# Altium schematic sheet Detection_Circuit_Sheet_01.SchDoc
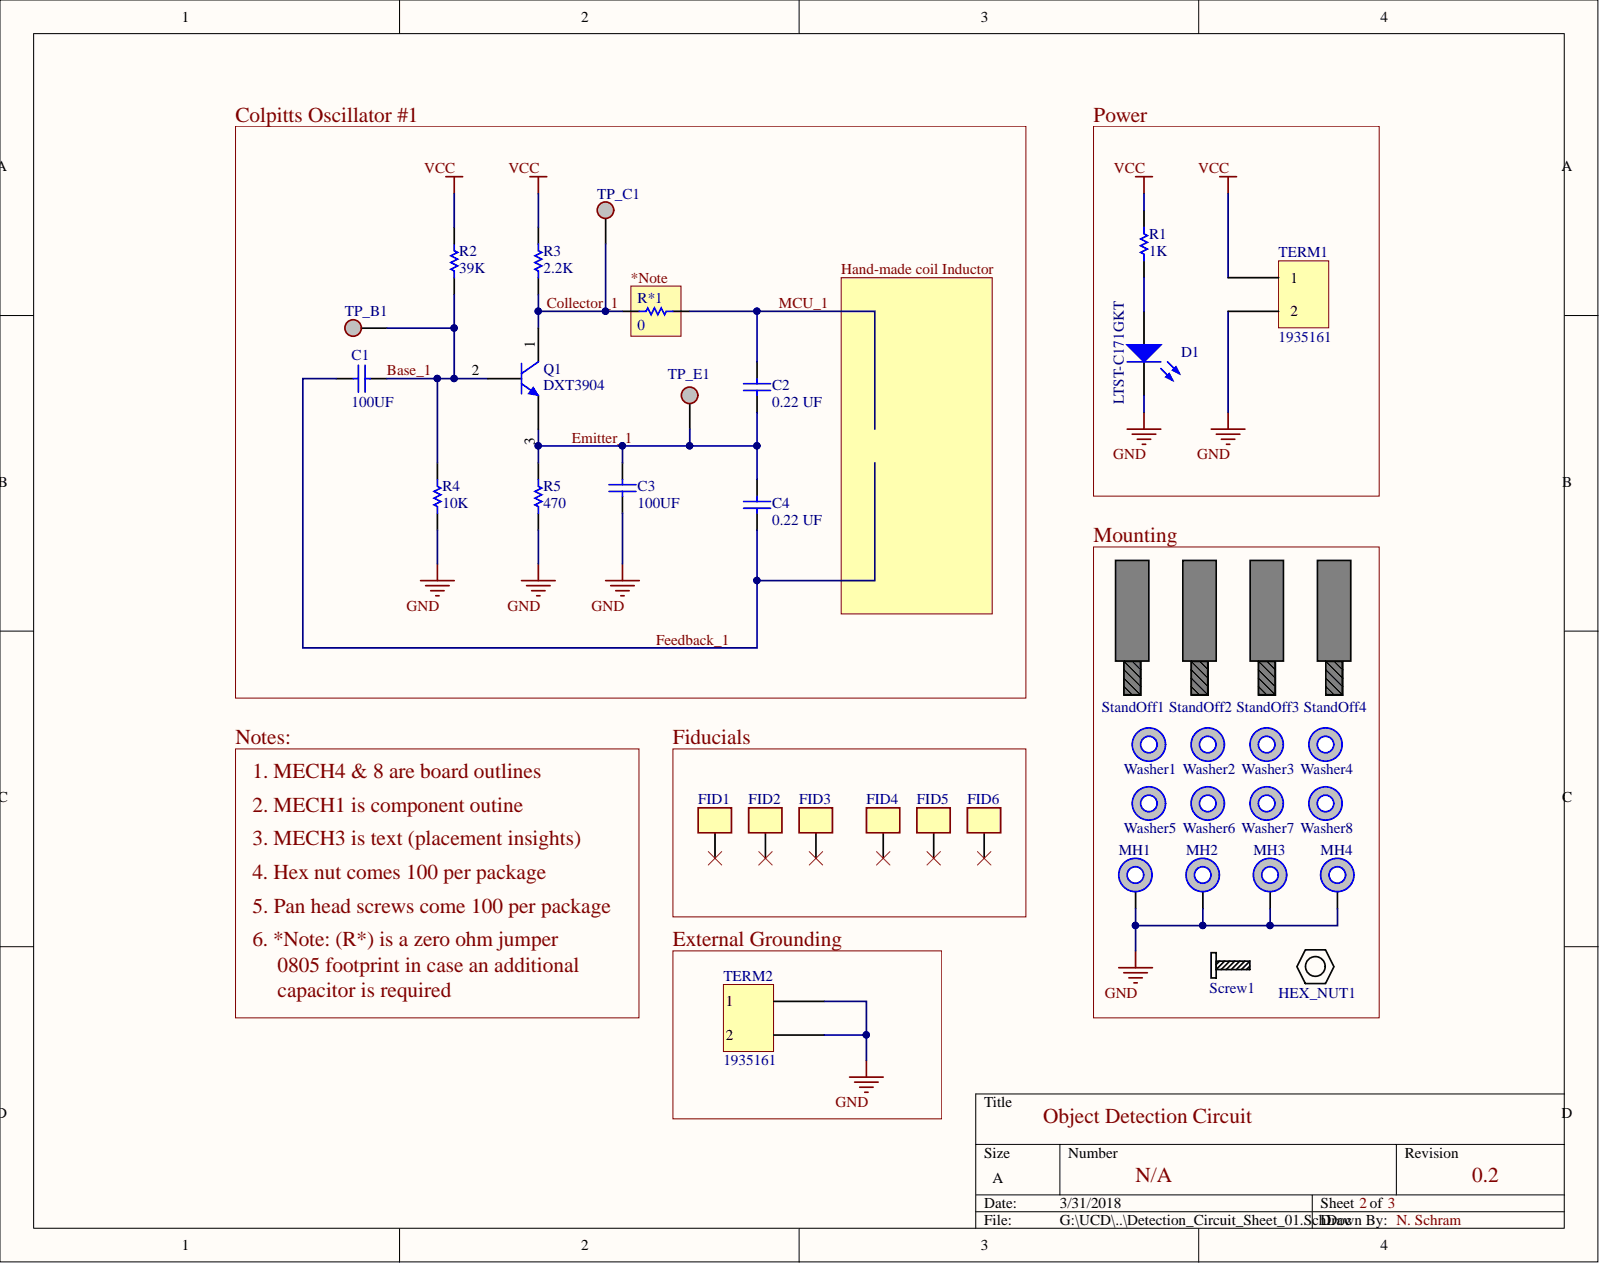
[diagram p0: Detection_Circuit_Sheet_01.SchDoc]

## Parts
C1  100UF  [C1210_M]
C2  08055C224JAT2A  [6-0805_N]  (value not drawn)
C3  100UF  [C1210_M]
C4  08055C224JAT2A  [6-0805_N]  (value not drawn)
D1  LTST-C171GKT  [6-0805_M]
FID1  Fiducial  [Fiducial]
FID2  Fiducial  [Fiducial]
FID3  Fiducial  [Fiducial]
FID4  Fiducial  [Fiducial]
FID5  Fiducial  [Fiducial]
FID6  Fiducial  [Fiducial]
HEX_NUT1  6-32 Hex Nut  (value not drawn)
MH1  #6 MH  [#6_MH]  (value not drawn)
MH2  #6 MH  [#6_MH]  (value not drawn)
MH3  #6 MH  [#6_MH]  (value not drawn)
MH4  #6 MH  [#6_MH]  (value not drawn)
Q1  DXT3904  [DXT3904-13]
R1  1K  [6-0805_M]
R2  39K  [6-0805_M]
R3  2.2K  [6-0805_M]
R4  10K  [6-0805_M]
R5  470  [6-0805_M]
R*1  0  [6-0805_M]
Screw1  6-32 Pan Head Screw  (value not drawn)
StandOff1  6-32 Stand Off  (value not drawn)
StandOff2  6-32 Stand Off  (value not drawn)
StandOff3  6-32 Stand Off  (value not drawn)
StandOff4  6-32 Stand Off  (value not drawn)
TERM1  1935161  [1935161]
TERM2  1935161  [1935161]
TP_B1  TestPoint  [TestPoint]  (value not drawn)
TP_C1  TestPoint  [TestPoint]  (value not drawn)
TP_E1  TestPoint  [TestPoint]  (value not drawn)
Washer1  Nylon Washer  (value not drawn)
Washer2  Nylon Washer  (value not drawn)
Washer3  Nylon Washer  (value not drawn)
Washer4  Nylon Washer  (value not drawn)
Washer5  Nylon Washer  (value not drawn)
Washer6  Nylon Washer  (value not drawn)
Washer7  Nylon Washer  (value not drawn)
Washer8  Nylon Washer  (value not drawn)

## Nets
GND: C3.1 D1.2 MH1.MH MH2.MH MH3.MH MH4.MH R4.1 R5.1 TERM1.2 TERM2.1 TERM2.2
VCC: R1.2 R2.2 R3.2 TERM1.1
BASE_1: C1.2 Q1.2 R2.1 R4.2 TP_B1.1
COLLECTOR_1: Q1.1 R3.1 R*1.1 TP_C1.1
EMITTER_1: C2.1 C3.2 C4.2 Q1.3 R5.2 TP_E1.1
FEEDBACK_1: C1.1 C4.1
MCU_1: C2.2 R*1.2
NetD1_1: D1.1 R1.1

Not connected: FID1 FID; FID2 FID; FID3 FID; FID4 FID; FID5 FID; FID6 FID

## Library data of the parts
C1 C3 = CC1210MKX5R7BB107: CAP CER 100UF 16V X5R 1210; Manufacturer: Yageo; Capacitance: 100µF; Tolerance: ±20%; Applications: General Purpose; Category: Capacitors; Component Kind: Standard; ComponentLink1Description: http://www.mouser.com/ds/2/447/Yageo_UPY-GP_NP0_16V-to-50V_9-358835.pdf; ComponentLink1URL: http://www.mouser.com/ds/2/447/Yageo_UPY-GP_NP0_16V-to-50V_9-358835.pdf; ComponentLink2Description: http://www.yageo.com/documents/recent/UPY-GPHC_X5R_4V-to-50V_25.pdf; ComponentLink2URL: http://www.yageo.com/documents/recent/UPY-GPHC_X5R_4V-to-50V_25.pdf; Family: Ceramic Capacitors; Features: -; Height - Seated (Max): -; Lead Spacing: -; Lead Style: -; Library Name: CC1210MKX5R7BB107.SchLib; Manufacturer Part Number: CC1210MKX5R7BB107; Mounting Type: Surface Mount, MLCC; Operating Temperature: -55°C ~ 85°C; Package / Case: 1210 (3225 Metric); Packaging: Tape & Reel (TR); Pricing 1: 1=1.82, 10=1.437, 100=1.0778 (USD); Ratings: -; Series: CC; Size / Dimension: 0.126" L x 0.098" W (3.20mm x 2.50mm); Stock 1: 19901; Supplier 1: Digi-Key; Supplier Part Number 1: 311-2052-1-ND; Temperature Coefficient: X5R; Thickness (Max): 0.110" (2.80mm); Voltage - Rated: 16V
C2 C4 = 08055C224JAT2A: CAP CER 0.22UF 50V X7R 0805; Manufacturer: AVX Corporation; Notification: Current market demand for these product types have resulted in fluctuating and extending lead times. Lead times may differ.; Tolerance: ±5%; Applications: General Purpose; Capacitance: 0.22 UF; Category: Capacitors; Component Kind: Standard; ComponentLink1Description: http://datasheets.avx.com/X7RDielectric.pdf; ComponentLink1URL: http://datasheets.avx.com/X7RDielectric.pdf; Features: -; Height - Seated (Max): -; Lead Spacing: -; Lead Style: -; Library Name: 08055C224JAT2A.SchLib; Manufacturer Part Number: 08055C224JAT2A; Mounting Type: Surface Mount, MLCC; Operating Temperature: -55°C ~ 125°C; Package / Case: 0805 (2012 Metric); Packaging: Cut Tape (CT); Part Status: Active; Pricing 1: 1=0.55, 10=0.387, 100=0.255, 500=0.18886, 1000=0.16053 (USD); Ratings: -; RoHS: RoHS Compliant; Size / Dimension: 0.079" L x 0.049" W (2.00mm x 1.25mm); Stock 1: 25172; Supplier 1: Digi-Key; Supplier Part Number 1: 478-7437-1-ND; Temperature Coefficient: X7R; Thickness (Max): 0.037" (0.94mm); Voltage - Rated: 50V
D1 = LED3: LED GREEN CLEAR 0805 SMD; Manufacturer: Vishay Lite-On; Chip Material: GaP; DELETED: Compliant; Dominant Wave Length: 569 nm; Emitting Color: Yellow Green; Forward Current: 30 mA; Forward Voltage: 2.6 V; High Brightness: No; Luminous Intensity: 12mcd; Main Category: Chip LED; Number of  Elements: 1; Peak Wavelength: 565 nm; Power Dissipation: 100 W; Product Depth (mm): 1.25 mm; Product Height (mm): 0.8 mm; Product Length (mm): 2 mm; Reverse Voltage: 5 V; Shape Type: Rectangular; Test Current (It): 10 mA; Type: Uni-Color; Viewing Angle: 130 deg; Component Kind: Standard; ComponentLink1Description: https://datasheet.ciiva.com/2110/ltst-c171gkt-2110000.pdf; ComponentLink1URL: https://datasheet.ciiva.com/2110/ltst-c171gkt-2110000.pdf; ComponentLink2Description: https://datasheet.ciiva.com/2110/ltst-c171gkt-2110001.pdf; ComponentLink2URL: https://datasheet.ciiva.com/2110/ltst-c171gkt-2110001.pdf; ComponentLink3Description: https://datasheet.ciiva.com/2110/ltst-c171gkt-2110002.pdf; ComponentLink3URL: https://datasheet.ciiva.com/2110/ltst-c171gkt-2110002.pdf; ComponentLink4Description: https://datasheet.ciiva.com/16396/ltst-c171gkt-16396549.pdf; ComponentLink4URL: https://datasheet.ciiva.com/16396/ltst-c171gkt-16396549.pdf; ComponentLink5Description: https://datasheet.ciiva.com/11773/0900766b80dc0296-11773991.pdf; ComponentLink5URL: https://datasheet.ciiva.com/11773/0900766b80dc0296-11773991.pdf; ComponentLink6Description: https://datasheet.ciiva.com/12400/lite-on-ltst-c171gkt-190133-12400647.pdf; ComponentLink6URL: https://datasheet.ciiva.com/12400/lite-on-ltst-c171gkt-190133-12400647.pdf; Lens Dimensions: 1.25X1.4X0.5 mm; Library Name: Miscellaneous Devices.IntLib; Lum Intensity: 12mcd; Manufacturer Part Number: LTST-C171GKT; Mounting: Surface Mount; Operating Temperature Classification: Industrial; Operating Temp Range: -55C to 85C; Package Type: Chip Led; Packaging: Tape and Reel; Pin Count: 2; Pricing 1: 1=0.3, 10=0.238, 100=0.1296, 250=0.0864, 500=0.0734, 1000=0.0562 (USD); Rad Hardened: No; Stock 1: 158224; Supplier 1: Digi-Key; Supplier Part Number 1: 160-1423-1-ND
HEX_NUT1 = HEX_NUT: HEX NUT 0.245" STEEL 6-32; Manufacturer Part Number: 4701; Brand: Keystone Electronics; Gender: Female; Plating: Zinc; Component Kind: Standard; ComponentLink1Description: https://datasheet.ciiva.com/41799/4701-41799174.pdf; ComponentLink1URL: https://datasheet.ciiva.com/41799/4701-41799174.pdf; ComponentLink2Description: https://datasheet.ciiva.com/18416/70181805-18416126.pdf; ComponentLink2URL: https://datasheet.ciiva.com/18416/70181805-18416126.pdf; Factory Pack Quantity: 100; Features: Universal Mounting Kits; Length: 6.22 mm; Library Name: 6-32_Hex_Nut.SchLib; Manufacturer: Keystone Electronics; Material: Steel; Pricing 1: 1=0.1, 10=0.049, 50=0.0342, 100=0.0293, 250=0.0283, 500=0.0244, 1000=0.0219, 2500=0.0195, 5000=0.0185 (USD); Product Category: Screws & Fasteners; RoHS: Details; Stock 1: 51671; Supplier 1: Digi-Key; Supplier Part Number 1: 36-4701-ND; Thickness: 2.41 mm; Thread Size: 6-32; Type: Nuts; Width: 6.22 mm
MH1-MH4 = MH: #6 Mounting Hole; Component Kind: Standard; Library Name: #6_MH.SchLib
Q1 = DXT3904-13: TRANS NPN 40V 0.2A SOT89-3; Manufacturer: Diodes; Brand: Diodes Incorporated; Collector- Base Voltage VCBO: 60 V; Collector-Emitter Saturation Voltage: 300 mV; Configuration: Single; DC Collector/Base Gain hfe Min: 30 at 100 mA, 1 V; Emitter- Base Voltage VEBO: 6 V; Gain Bandwidth Product fT: 300 MHz; Maximum DC Collector Current: 200 mA; Pd - Power Dissipation: 1000 mW; Unit Weight: 0.001834 oz; Collector- Emitter Voltage VCEO Max: 40 V; Component Kind: Standard; ComponentLink1Description: https://datasheet.ciiva.com/979/ds31141-979988.pdf; ComponentLink1URL: https://datasheet.ciiva.com/979/ds31141-979988.pdf; ComponentLink2Description: https://datasheet.ciiva.com/4724/ds31141-4724919.pdf; ComponentLink2URL: https://datasheet.ciiva.com/4724/ds31141-4724919.pdf; ComponentLink3Description: https://datasheet.ciiva.com/17303/ds31141-17303788.pdf; ComponentLink3URL: https://datasheet.ciiva.com/17303/ds31141-17303788.pdf; ComponentLink4Description: https://datasheet.ciiva.com/11206/ds31141-11206239.pdf; ComponentLink4URL: https://datasheet.ciiva.com/11206/ds31141-11206239.pdf; Factory Pack Quantity: 2500; Height: 1.5 mm; Length: 4.5 mm; Library Name: DXT3904-13.SchLib; Manufacturer Part Number: DXT3904-13; Maximum Operating Temperature: + 150 C; Minimum Operating Temperature: - 55 C; Mounting Style: SMD/SMT; Package / Case: SOT-89; Packaging: Reel; Pricing 1: 1=0.53, 10=0.426, 100=0.29, 500=0.2175, 1000=0.1632 (USD); Product Category: Bipolar Transistors - BJT; RoHS: True; Series: DXT3904; Stock 1: 10942; Supplier 1: Digi-Key; Supplier Part Number 1: DXT3904-13DICT-ND; Transistor Polarity: NPN; Width: 2.48 mm
R1 = RNCP0805FTD1K00: RES SMD 1K OHM 1% 1/4W 0805; Manufacturer: Stackpole Electronics; Power (Watts): 0.25W, 1/4W; Temperature Coefficient: ±100ppm/°C; Category: Resistors; Component Kind: Standard; ComponentLink1Description: http://www.seielect.com/Catalog/SEI-rncp.pdf; ComponentLink1URL: http://www.seielect.com/Catalog/SEI-rncp.pdf; Composition: Thin Film; Family: Chip Resistor - Surface Mount; Features: Anti-Sulfur, Moisture Resistant; Height: 0.024" (0.60mm); Library Name: RNCP0805FTD1K00.SchLib; Manufacturer Part Number: RNCP0805FTD1K00; Number of Terminations: 2; Operating Temperature: -55°C ~ 155°C; Package / Case: 0805 (2012 Metric); Packaging: Tape & Reel (TR); Pricing 1: 1=0.1, 10=0.037, 100=0.015, 1000=0.0067, 2500=0.0058 (USD); Resistance (Ohms): 1k; Series: RNCP; Size / Dimension: 0.079" L x 0.049" W (2.00mm x 1.25mm); Stock 1: 107354; Supplier 1: Digi-Key; Supplier Device Package: 0805; Supplier Part Number 1: RNCP0805FTD1K00CT-ND; Tolerance: ±1%
R2 = ERJ-PB6D3902V: RES SMD 39K OHM 0.5% 1/4W 0805; Manufacturer: Panasonic; Power (Watts): 0.25W, 1/4W; Temperature Coefficient: ±50ppm/°C; Category: Resistors; Component Kind: Standard; ComponentLink1Description: https://industrial.panasonic.com/sa/system/files/data/download/catalog/id_fixed-resistors_e_2.pdf; ComponentLink1URL: https://industrial.panasonic.com/sa/system/files/data/download/catalog/id_fixed-resistors_e_2.pdf; Composition: Thick Film; Failure Rate: -; Family: Chip Resistor - Surface Mount; Features: Automotive AEC-Q200, Pulse Withstanding; Height - Seated (Max): 0.028" (0.70mm); Library Name: ERJ-PB6D3902V.SchLib; Manufacturer Part Number: ERJ-PB6D3902V; Number of Terminations: 2; Operating Temperature: -55°C ~ 155°C; Package / Case: 0805 (2012 Metric); Packaging: Tape & Reel (TR); Part Status: Active; Pricing 1: 1=0.24, 10=0.204, 25=0.1488, 50=0.1078, 100=0.0799, 250=0.0614, 500=0.0483, 1000=0.0335 (USD); Resistance (Ohms): 39k; Series: ERJ; Size / Dimension: 0.079" L x 0.049" W (2.00mm x 1.25mm); Stock 1: 2236; Supplier 1: Digi-Key; Supplier Device Package: 0805; Supplier Part Number 1: P21199CT-ND; Tolerance: ±0.5%
R3 = ERJ-PB6D2201V: RES SMD 2.2K OHM 0.5% 1/4W 0805; Manufacturer: Panasonic; Power (Watts): 0.25W, 1/4W; Temperature Coefficient: ±50ppm/°C; Category: Resistors; Component Kind: Standard; ComponentLink1Description: https://industrial.panasonic.com/sa/system/files/data/download/catalog/id_fixed-resistors_e_2.pdf; ComponentLink1URL: https://industrial.panasonic.com/sa/system/files/data/download/catalog/id_fixed-resistors_e_2.pdf; Composition: Thick Film; Failure Rate: -; Family: Chip Resistor - Surface Mount; Features: Automotive AEC-Q200, Pulse Withstanding; Height - Seated (Max): 0.028" (0.70mm); Library Name: ERJ-PB6D2201V.SchLib; Manufacturer Part Number: ERJ-PB6D2201V; Number of Terminations: 2; Operating Temperature: -55°C ~ 155°C; Package / Case: 0805 (2012 Metric); Packaging: Tape & Reel (TR); Part Status: Active; Pricing 1: 1=0.24, 10=0.204, 25=0.1488, 50=0.1078, 100=0.0799, 250=0.0614, 500=0.0483, 1000=0.0335 (USD); Resistance (Ohms): 2.2k; Series: ERJ; Size / Dimension: 0.079" L x 0.049" W (2.00mm x 1.25mm); Stock 1: 3147; Supplier 1: Digi-Key; Supplier Device Package: 0805; Supplier Part Number 1: P21055CT-ND; Tolerance: ±0.5%
R4 = RNCP0805FTD10K0: RES SMD 10K OHM 1% 1/4W 0805; Manufacturer: Stackpole Electronics; Power (Watts): 0.25W, 1/4W; Temperature Coefficient: ±100ppm/°C; Category: Resistors; Component Kind: Standard; ComponentLink1Description: http://www.seielect.com/Catalog/SEI-rncp.pdf; ComponentLink1URL: http://www.seielect.com/Catalog/SEI-rncp.pdf; Composition: Thin Film; Family: Chip Resistor - Surface Mount; Features: Anti-Sulfur, Moisture Resistant; Height: 0.024" (0.60mm); Library Name: RNCP0805FTD10K0.SchLib; Manufacturer Part Number: RNCP0805FTD10K0; Number of Terminations: 2; Operating Temperature: -55°C ~ 155°C; Package / Case: 0805 (2012 Metric); Packaging: Tape & Reel (TR); Pricing 1: 1=0.1, 10=0.037, 100=0.015, 1000=0.0067, 2500=0.0058 (USD); Resistance (Ohms): 10k; Series: RNCP; Size / Dimension: 0.079" L x 0.049" W (2.00mm x 1.25mm); Stock 1: 103823; Supplier 1: Digi-Key; Supplier Device Package: 0805; Supplier Part Number 1: RNCP0805FTD10K0CT-ND; Tolerance: ±1%
R5 = ERJ-PB6D4700V: RES SMD 470 OHM 0.5% 1/4W 0805; Manufacturer: Panasonic; Power (Watts): 0.25W, 1/4W; Temperature Coefficient: ±50ppm/°C; Category: Resistors; Component Kind: Standard; ComponentLink1Description: https://industrial.panasonic.com/sa/system/files/data/download/catalog/id_fixed-resistors_e_2.pdf; ComponentLink1URL: https://industrial.panasonic.com/sa/system/files/data/download/catalog/id_fixed-resistors_e_2.pdf; Composition: Thick Film; Failure Rate: -; Family: Chip Resistor - Surface Mount; Features: Automotive AEC-Q200, Pulse Withstanding; Height - Seated (Max): 0.028" (0.70mm); Library Name: ERJ-PB6D4700V.SchLib; Manufacturer Part Number: ERJ-PB6D4700V; Number of Terminations: 2; Operating Temperature: -55°C ~ 155°C; Package / Case: 0805 (2012 Metric); Packaging: Cut Tape (CT); Part Status: Active; Pricing 1: 1=0.24, 10=0.204, 25=0.1488, 50=0.1078, 100=0.0799, 250=0.0614, 500=0.0483, 1000=0.0335 (USD); Resistance (Ohms): 470; Series: ERJ; Size / Dimension: 0.079" L x 0.049" W (2.00mm x 1.25mm); Stock 1: 2688; Supplier 1: Digi-Key; Supplier Device Package: 0805; Supplier Part Number 1: P20981CT-ND; Tolerance: ±0.5%
R*1 = ERJ-6GEY0R00V: RES SMD 0 OHM JUMPER 1/8W 0805; Manufacturer: Panasonic ECG; Rated Power: 0.125 W; Resistance: 0 O; Temp Coefficient: 0 ppm/°C; Tolerance (%): 0 %; Component Kind: Standard; ComponentLink1Description: https://datasheet.ciiva.com/28695/1678796-28695877.pdf; ComponentLink1URL: https://datasheet.ciiva.com/28695/1678796-28695877.pdf; ComponentLink2Description: https://datasheet.ciiva.com/11821/0900766b80f806dd-11821735.pdf; ComponentLink2URL: https://datasheet.ciiva.com/11821/0900766b80f806dd-11821735.pdf; ComponentLink3Description: https://datasheet.ciiva.com/43460/1678796-43460073.pdf; ComponentLink3URL: https://datasheet.ciiva.com/43460/1678796-43460073.pdf; ComponentLink4Description: https://datasheet.ciiva.com/9117/aoa0000ce1-9117354.pdf; ComponentLink4URL: https://datasheet.ciiva.com/9117/aoa0000ce1-9117354.pdf; ComponentLink5Description: https://datasheet.ciiva.com/46377/1678796-46377866.pdf; ComponentLink5URL: https://datasheet.ciiva.com/46377/1678796-46377866.pdf; ComponentLink6Description: https://datasheet.ciiva.com/36526/1989607-36526237.pdf; ComponentLink6URL: https://datasheet.ciiva.com/36526/1989607-36526237.pdf; ComponentLink7Description: https://datasheet.ciiva.com/17215/aoa0000ce1-17215390.pdf; ComponentLink7URL: https://datasheet.ciiva.com/17215/aoa0000ce1-17215390.pdf; ComponentLink8Description: https://datasheet.ciiva.com/16512/aoa0000ce1-16512111.pdf; ComponentLink8URL: https://datasheet.ciiva.com/16512/aoa0000ce1-16512111.pdf; Library Name: ERJ-6GEY0R00V.SchLib; Manufacturer Part Number: ERJ-6GEY0R00V; Pricing 1: 1=0.1, 10=0.053, 25=0.038, 50=0.029, 100=0.0214, 250=0.0163, 500=0.0131, 1000=0.0096 (USD); Size Code: 0805; Stock 1: 6840904; Supplier 1: Digi-Key; Supplier Part Number 1: P0.0ACT-ND
Screw1 = 6-32 Screw: MACHINE SCREW PAN PHILLIPS 6-32; Manufacturer: B&F Fastener; Manufacturer Part Number: PMSSS6320050PH; Dynamic Catalog: Stainless Steel Screws; Head Height: 0.092" (2.34mm); Kits: 1608-KIT-ND  - STAINLESS STL HARDWARE #6,#8,#10; Other Names: H711; Category: Hardware, Fasteners, Accessories; Component Kind: Standard; ComponentLink1Description: https://datasheet.ciiva.com/1034/machinepancombo-1034179.pdf; ComponentLink1URL: https://datasheet.ciiva.com/1034/machinepancombo-1034179.pdf; Drive Type: Phillips; Family: Screws, Bolts; Length - Below Head: 0.500" (12.70mm) 1/2"; Length - Overall: 0.592" (15.04mm); Library Name: 6-32_Screw.SchLib; Material: Stainless Steel; Pricing 1: 100=0.0773, 1000=0.0618 (USD); Screw Head Type: Pan Head; Standard Package: 100; Stock 1: 15276; Supplier 1: Digi-Key; Supplier Part Number 1: H711-ND; Thread Size: 6-32; Type: Machine Screw
StandOff1-StandOff4 = STANDOFF: HEX STANDOFF 6-32 ALUMINUM 3/4"; Manufacturer: Keystone Electronics; Manufacturer Part Number: 8416; Between Board Height: 0.750" (19.05mm) 3/4"; Gender: Male, Female; Plating: Iridite; Screw, Thread Size: #6-32; Threaded/Unthreaded: Threaded; Category: Hardware, Fasteners, Accessories; Color: -; Component Kind: Standard; ComponentLink1Description: https://datasheet.ciiva.com/5225/m60-2p70-311182-5225146.pdf; ComponentLink1URL: https://datasheet.ciiva.com/5225/m60-2p70-311182-5225146.pdf; ComponentLink2Description: https://datasheet.ciiva.com/14533/70181845-14533167.pdf; ComponentLink2URL: https://datasheet.ciiva.com/14533/70181845-14533167.pdf; Diameter - Inside: -; Diameter - Outside: 0.250" (6.35mm) 1/4" Hex; Family: Board Spacers, Standoffs; Features: -; Length - Overall: 1.000" (25.40mm) 1"; Library Name: 6-32_Stand_Off.SchLib; Material: Aluminum; Pricing 1: 1=0.74, 10=0.55, 50=0.44, 100=0.4217, 250=0.385, 1000=0.3079, 2500=0.2751, 5000=0.2659 (USD); Series: -; Stock 1: 20629; Supplier 1: Digi-Key; Supplier Part Number 1: 36-8416-ND; Type: Hex Standoff; Weight: -
TERM1 TERM2 = 1935161: TERM BLOCK PCB 2POS 5.0MM GREEN; Manufacturer: Phoenix Contact; Mating Orientation: Horizontal with Board; Pitch: 0.197" (5.00mm); Positions Per Level: 2; Screw Thread: M2.6; Torque - Screw: 0.35-0.4 Nm (3.1-3.5 Lb-In); Wire Gauge: 12-26 AWG; Wire Termination: Screw; Category: Connectors, Interconnects; Clamp Material - Plating: -; Color: Green; Component Kind: Standard; ComponentLink1Description: https://media.digikey.com/pdf/Data%20Sheets/Phoenix%20Contact%20PDFs/1935161.pdf; ComponentLink1URL: https://media.digikey.com/pdf/Data%20Sheets/Phoenix%20Contact%20PDFs/1935161.pdf; Contact Material - Plating: -; Features: Interlocking (Side); Housing Material: Polyamide (PA), Nylon; Library Name: 1935161.SchLib; Manufacturer Part Number: 1935161; Mounting Type: Through Hole; Operating Temperature: -; Packaging: Bulk; Part Status: Active; Pricing 1: 1=0.41, 10=0.391, 50=0.374, 100=0.3638, 250=0.34, 500=0.3128, 1000=0.289 (USD); RoHS: RoHS Compliant; Screw Material - Plating: -; Stock 1: 18819; Supplier 1: Digi-Key; Supplier Part Number 1: 277-1667-ND
TP_B1 TP_C1 TP_E1 = TestPoint: Test Point; Component Kind: Standard; Library Name: TestPoint.SchLib
Washer1-Washer8 = Washer: MACHINE SCREW PAN PHILLIPS 6-32; Manufacturer: Keystone Electronics; Manufacturer Part Number: 3349; Catalog Drawings: Flat Washer Side; Dynamic Catalog: Nylon and Fiber Flat Washers; Other Names: 3349K; Thread/Screw/Hole Size: #6; Category: Hardware, Fasteners, Accessories; Component Kind: Standard; ComponentLink1Description: https://datasheet.ciiva.com/16215/m60-2p131-16215696.pdf; ComponentLink1URL: https://datasheet.ciiva.com/16215/m60-2p131-16215696.pdf; Diameter - Inside: 0.140" (3.56mm); Diameter - Outside: 0.312" (7.92mm); Family: Washers; Library Name: #6_Nylon_Washer.SchLib; Material: Nylon; Pricing 1: 10=0.064, 50=0.0564, 100=0.0393, 250=0.0339, 500=0.0325, 1000=0.0285, 2500=0.0257, 5000=0.0244 (USD); Standard Package: 100; Stock 1: 26447; Supplier 1: Digi-Key; Supplier Part Number 1: 3349K-ND; Thickness: 0.032" (0.81mm); Type: Flat
FID1-FID6 = Fiducial: Component Kind: Standard; Description: Fiducial; Library Name: Fiducial.SchLib
